# Altium schematic sheet nRF24L01-Module.SchDoc
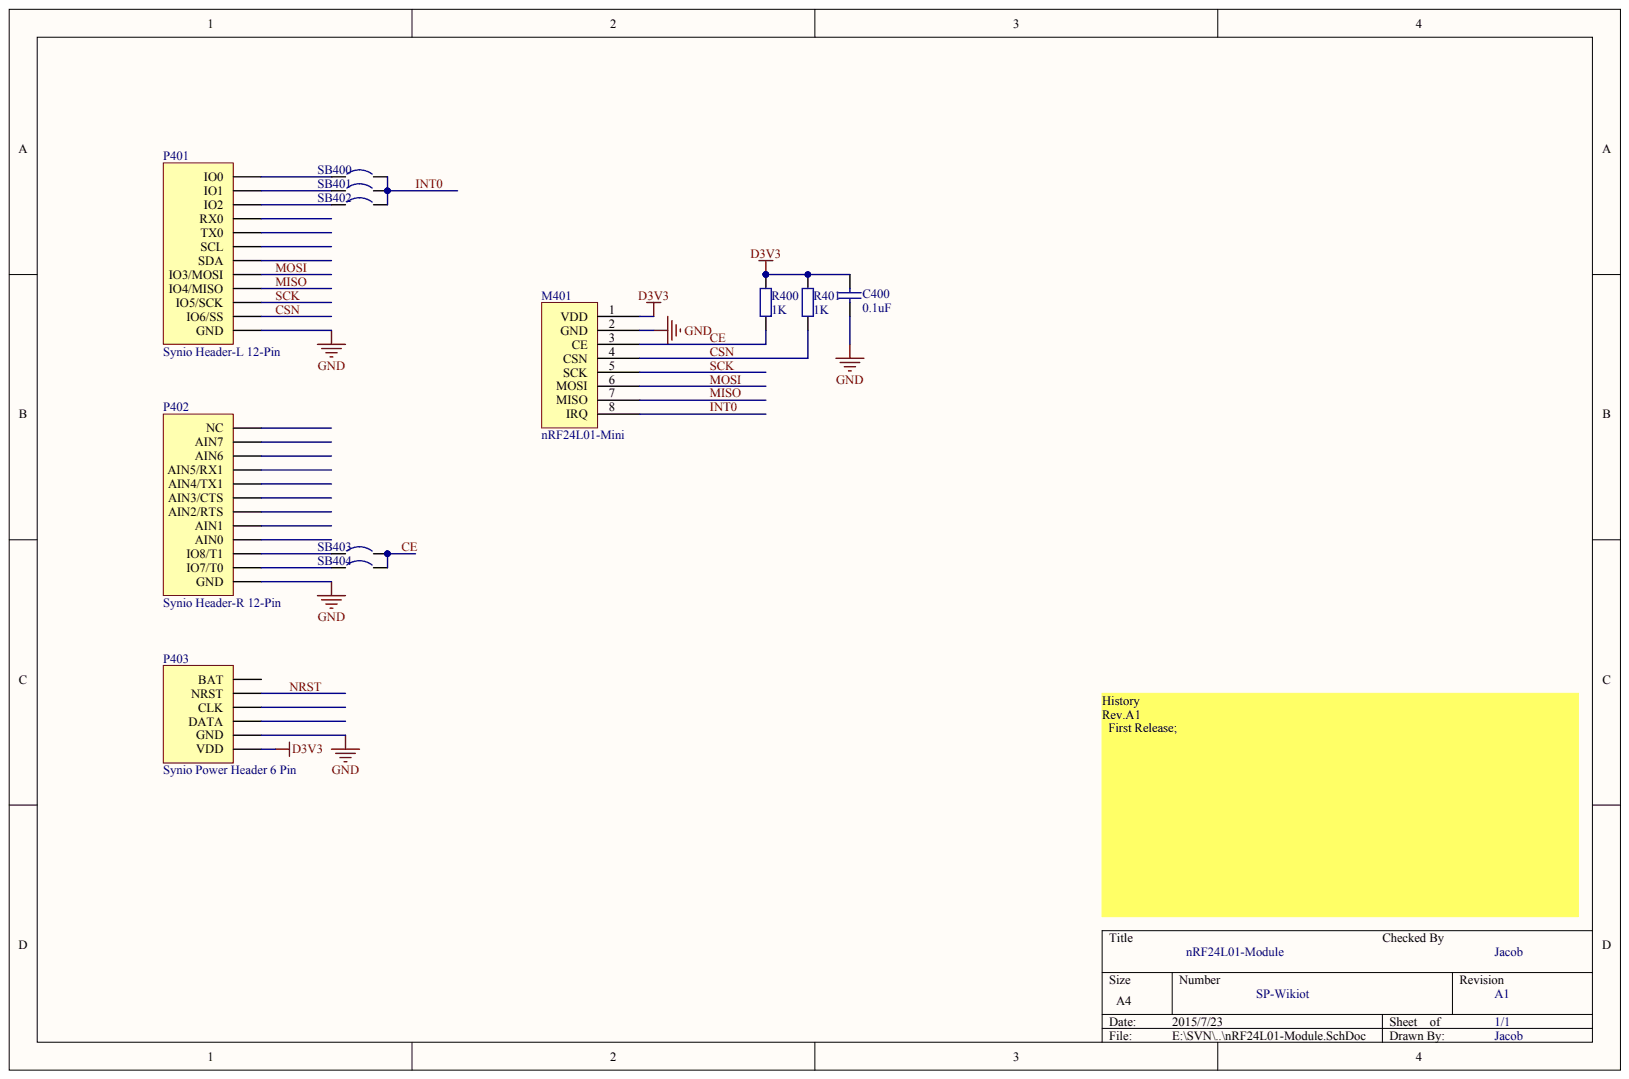
[diagram p0: nRF24L01-Module.SchDoc]

## Parts
C400  0.1uF
M401  nRF24L01-Mini
P401  Synio Header-L 12-Pin
P402  Synio Header-R 12-Pin
P403  Synio Power Header 6 Pin
R400  1K
R401  1K
SB400  Circuit Breaker  (value not drawn)
SB401  Circuit Breaker  (value not drawn)
SB402  Circuit Breaker  (value not drawn)
SB403  Circuit Breaker  (value not drawn)
SB404  Circuit Breaker  (value not drawn)

## Nets
D3V3: C400.1 M401.1(VDD) P403.1(VDD) R400.1 R401.1
GND: C400.2 M401.2(GND) P401.1(GND) P402.1(GND) P403.2(GND)
CE: M401.3(CE) R400.2 SB403.2 SB404.2
CSN: M401.4(CSN) P401.2(IO6/SS) R401.2
INT0: M401.8(IRQ) SB400.2 SB401.2 SB402.2
MISO: M401.7(MISO) P401.4(IO4/MISO)
MOSI: M401.6(MOSI) P401.5(IO3/MOSI)
NRST: P403.5(NRST)
SCK: M401.5(SCK) P401.3(IO5/SCK)
NetP401_10: P401.10(IO2) SB402.1
NetP401_11: P401.11(IO1) SB401.1
NetP401_12: P401.12(IO0) SB400.1
NetP402_2: P402.2(IO7/T0) SB404.1
NetP402_3: P402.3(IO8/T1) SB403.1

Not connected: P401 6(SDA) 7(SCL) 8(TX0) 9(RX0); P402 4(AIN0) 5(AIN1) 6(AIN2/RTS) 7(AIN3/CTS) 8(AIN4/TX1) 9(AIN5/RX1) 10(AIN6) 11(AIN7) 12(NC); P403 3(DATA) 4(CLK) 6(BAT)

## Library data of the parts
SB400-SB404 = (no symbol name): Solder: YES; Published: 24-Mar-1999; Revision: July-2002: Re-released for DXP Platform.; Publisher: Altium Limited
C400 M401 P401-P403 R400 R401 = (no symbol name)
  C400: Published: 8-Jun-2000; LatestRevisionDate: 17-Jul-2002; LatestRevisionNote: Re-released for DXP Platform.; PackageReference: RAD-0.3; Value: 0.1uF
  P401 P402: LatestRevisionDate: 17-Jul-2002; LatestRevisionNote: Re-released for DXP Platform.
  R400 R401: Published: 8-Jun-2000; LatestRevisionDate: 17-Jul-2002; LatestRevisionNote: Re-released for DXP Platform.; PackageReference: AXIAL-0.4; Value: 1K
  P401 P402 R400 R401: Publisher: Altium Limited
